# Altium schematic sheet Percepção_Externa.SchDoc(Percepção_Externa)
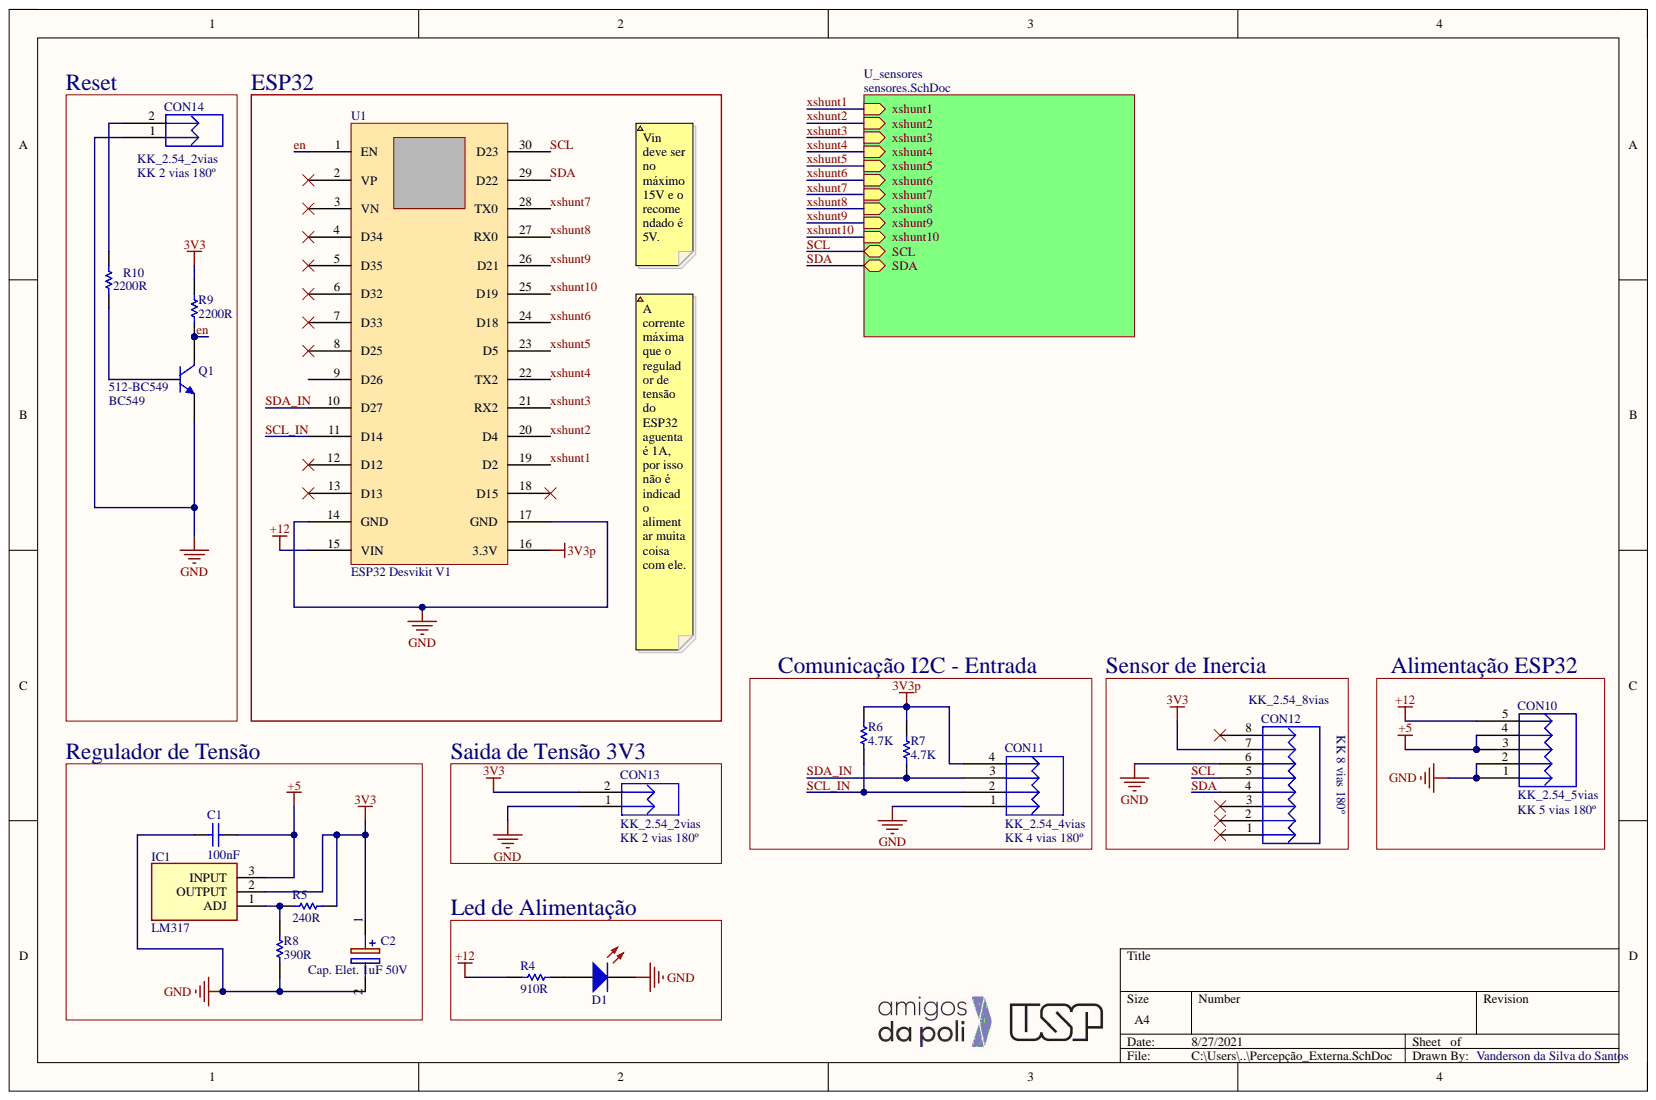
[diagram p0: Percepção_Externa.SchDoc]

## Parts
C1  100nF  [CAP CER DISK 100NF 50V]
C2  Cap. Elet. 1uF 50V  [Cap. Elet. 470uF 16V]
CON10  KK_2.54_5vias  Valor: KK 5 vias 180º  [KK_5vias_180°]
CON11  KK_2.54_4vias  Valor: KK 4 vias 180º  [KK_4vias_180°]
CON12  KK_2.54_8vias  Valor: KK 8 vias 180º  [KK_8vias_180°]
CON13  KK_2.54_2vias  Valor: KK 2 vias 180º  [KK_2VIAS_180º]
CON14  KK_2.54_2vias  Valor: KK 2 vias 180º  [KK_2VIAS_180º]
D1  LED 5MM RED  [LED 5MM RED]  (value not drawn)
IC1  LM317  [TO254P467X1016X1971-3P]
Q1  BC549  Supplier Part Number 1: 512-BC549  [TO92]
R4  Resistance (Ohms): 910R  [RES 470R 1/4W CARBON FILM]  (hidden comment: RES 470R 1/4W CARBON FILM)
R5  Resistance (Ohms): 240R  [RES 470R 1/4W CARBON FILM]  (hidden comment: RES 470R 1/4W CARBON FILM)
R6  4.7K  [RES 4.7K 1/4W CARBON FILM]
R7  4.7K  [RES 4.7K 1/4W CARBON FILM]
R8  Resistance (Ohms): 390R  [RES 470R 1/4W CARBON FILM]  (hidden comment: RES 470R 1/4W CARBON FILM)
R9  Resistance (Ohms): 2200R  [RES 470R 1/4W CARBON FILM]  (hidden comment: RES 470R 1/4W CARBON FILM)
R10  Resistance (Ohms): 2200R  [RES 470R 1/4W CARBON FILM]  (hidden comment: RES 470R 1/4W CARBON FILM)
U1  value ESP32 Desvikit V1  [ESP32_Desvikit_v1]  (hidden comment: microcontrolador)

## Nets
3V3: C2.1 CON12.7 CON13.2 IC1.2 R5.2 R9.2
3V3p: CON11.4 R6.1 R7.1 U1.16(3.3V)
+5: C1.2 CON10.3 CON10.4 IC1.3
+12: CON10.5 R4.2 U1.15(VIN)
GND: C1.1 C2.2 CON10.1 CON10.2 CON11.1 CON12.6 CON13.1 CON14.1 D1.2 Q1.3 R8.1 U1.14(GND) U1.17(GND)
en: Q1.1 R9.1 U1.1(EN)
SCL: CON12.5 U1.30(D23)
SCL_IN: CON11.2 R6.2 U1.11(D14)
SDA: CON12.4 U1.29(D22)
SDA_IN: CON11.3 R7.2 U1.10(D27)
xshunt1: U1.19(D2)
xshunt2: U1.20(D4)
xshunt3: U1.21(RX2)
xshunt4: U1.22(TX2)
xshunt5: U1.23(D5)
xshunt6: U1.24(D18)
xshunt7: U1.28(TX0)
xshunt8: U1.27(RX0)
xshunt9: U1.26(D21)
xshunt10: U1.25(D19)
NetCON12_1: CON12.1
NetCON12_2: CON12.2
NetCON12_3: CON12.3
NetCON12_8: CON12.8
NetCON14_2: CON14.2 R10.2
NetD1_1: D1.1 R4.1
NetIC1_1: IC1.1 R5.1 R8.2
NetQ1_2: Q1.2 R10.1
NetU1_2: U1.2(VP)
NetU1_3: U1.3(VN)
NetU1_4: U1.4(D34)
NetU1_5: U1.5(D35)
NetU1_6: U1.6(D32)
NetU1_7: U1.7(D33)
NetU1_8: U1.8(D25)
NetU1_9: U1.9(D26)
NetU1_12: U1.12(D12)
NetU1_13: U1.13(D13)
NetU1_18: U1.18(D15)

## Library data of the parts
C1 = CAP CER DISK 100NF 50V: Manufacturer Part Number: IMP14925; Capacitance: 0.1uF; Capacitance Tolerance: +80%, -20%; Capacitor Terminals: Radial Leaded; Dielectric Characteristic: Y5; Product Range: MCFY Series; SVHC: No SVHC (15-Jun-2015); Voltage Rating: 50; Capacitance Tolerance ±: +80%, -20%; Component Kind: Standard; ComponentLink1Description: http://loja.multcomercial.com.br/capacitor-ceramico-disco-100kpf-50v-104-100k-100nf-0-1uf-cod-1351.html; ComponentLink1URL: http://loja.multcomercial.com.br/capacitor-ceramico-disco-100kpf-50v-104-100k-100nf-0-1uf-cod-1351.html; Description: CAP CER DISK 100NF 50V; Lead Spacing: 5; Library Name: Capacitores_SCHLIB.SchLib; Manufacturer: -; Operating Temperature Max: 85; Operating Temperature Min: -25; Operating Temperature Range: -25°C to +85°C<!--FANDB--><div class="features-and-benefits-container"><div class="features-and-benefits-description"><span class="features-benefits-value">The MCFY series from Multicomp are radial leaded ceramic disc capacitors. The capacitance values ranges from 6800pF to 0.22?F.</span></div><div class="features-and-benefits-list"><ul class="features-and-benefits-list-list"><li class="features-and-benefits-list-item">Large capacitance in small size</li><li class="features-and-benefits-list-item">Rated voltage of 50V</li><li class="features-and-benefits-list-item">80%/-20% tolerance</li><li class="features-and-benefits-list-item">Operating temperature range -25°C to +85; Packaging: Each; Pricing 1: 1=0.10 (BRL); RoHS: YES; Stock 1: 115809; Supplier 1: Mult Comercial; Supplier Part Number 1: Capacitor Cerâmico Disco 100Kpf/50V (104/100K/100nF/0.1uF) cód. 1351
C2 = Cap. Elet. 470 uF 16V: Aluminum Organic Polymer Capacitors 16volts 470uF ESR 10mohm; Manufacturer_Name: Panasonic; Manufacturer_Part_Number: 16SEPC470M; Arrow Part Number: 16SEPC470M; Arrow Price/Stock: https://www.arrow.com/en/products/16sepc470m/panasonic; Component Kind: Standard; Datasheet Link: http://industrial.panasonic.com/www-cgi/jvcr13pz.cgi?E+CI+4+AAB8010+16SEPC470M+8+WW; Height: 13mm; Library Name: esp32.SchLib; Mouser Part Number: 667-16SEPC470M; Mouser Price/Stock: https://www.mouser.co.uk/ProductDetail/Panasonic/16SEPC470M?qs=OE1iw1LrrPEdZuWyF2l%252B5w%3D%3D
CON10 = KK_2.54_5vias: Conector KK 2.54mm 5 vias; Descrição: Conector KK 3 vias com passo 2.54mm; Encapsulamento: PTH - 180º; Modelo: 3 vias - 180º; Component Kind: Standard; Library Name: Conectores_Sch.SchLib
CON11 = KK_2.54_4vias: Conector KK 2.54mm 4 vias; Descrição: Conector KK 3 vias com passo 2.54mm; Encapsulamento: PTH - 180º; Modelo: 3 vias - 180º; Component Kind: Standard; Library Name: Conectores_Sch.SchLib
CON12 = KK_2.54_8vias: Conector KK 2.54mm 8 vias; Descrição: Conector KK 3 vias com passo 2.54mm; Encapsulamento: PTH - 180º; Modelo: 3 vias - 180º; Component Kind: Standard; Library Name: Conectores_Sch.SchLib
CON13 CON14 = KK_2.54_2vias: Conector KK 2.54mm 2 vias; Descrição: Conector KK 3 vias com passo 2.54mm; Encapsulamento: PTH - 180º; Modelo: 2 vias - 180º; Component Kind: Standard; Library Name: Conectores_Sch.SchLib
D1 = LED 5MM RED: Manufacturer: Paralight; Manufacturer Part Number: IMP585; Bulb Size: 3mm; Current If Luminous Intensity: 2; Dominant Wavelength: 625; External Diameter: 2.9; External Length / Height: 4.6; Forward Current If: 20; Forward Voltage VF Max: 2.5; Lead Cross-section Depth: 0.5; LED / Lamp Size: 3mm / T-1; LED Mounting: Through Hole; LED Type: Standard; Lens Shape: Round; Luminous Intensity: 3; Luminous Intensity @ If Min: 0.7; Peak Forward Current: 7; PIV Max: 5; SVHC: No SVHC (15-Jun-2015); Viewing Angle: 60°; Voltage Vf Typ: 2.2V<!--FANDB--><div class="features-and-benefits-container"><div class="features-and-benefits-description"><span class="features-benefits-value">The L-934LID from Kingbright is a through hole round shape high efficiency red colour LED. The device is made of gallium arsenide phosphide on gallium phosphide orange light emitting diode. This LED is widely used because of low power consumption, long life and solid state reliability.</span></div><div class="features-and-benefits-list"><ul class="features-and-benefits-list-list"><li class="features-and-benefits-list-item">Standard bulb size of T-1 (3mm)</li><li class="features-and-benefits-list-item">Reliable and rugged</li><li class="features-and-benefits-list-item">Viewing angle is 60°</li><li class="features-and-benefits-list-item">Luminous intensity of 3mcd at 2mA</li><li class="features-and-benefits-list-item">Forward voltage is 2.0V</li><li class="features-and-benefits-list-item">Forward current (If) is 20mA</li><li class="features-and-benefits-list-item">Dominant wavelength is 625nm at IF=20mA</li><li class="features-and-benefits-list-item">Peak wavelength is 627nm at IF=20; Component Kind: Standard; ComponentLink1Description: http://loja.multcomercial.com.br/led-3mm-vermelho-difuso-l-314hd-paralight.html; ComponentLink1URL: http://loja.multcomercial.com.br/led-3mm-vermelho-difuso-l-314hd-paralight.html; Description: LED 5MM RED; Forward Current If Max: 20; Forward Voltage: 2; Lead Cross-section Width: 0.5; Lead Spacing: 2.54; LED Colour: Red; Library Name: esp32.SchLib; Luminous Intensity Typ: 3; Mounting Type: PCB Through Hole; MSL: -; No. of Pins: 2; Operating Temperature Max: 85; Operating Temperature Min: -40; Operating Temperature Range: -40°C to +85; Packaging: Each; Peak Wavelength: 625; Pricing 1: 1=0.15 (BRL); RoHS: YES; Stock 1: 35260; Supplier 1: Mult Comercial; Supplier Part Number 1: Led 3mm Vermelho Difuso L-314HD - Paralight; Wavelength Typ: 625
IC1 = LM317: Integrated Circuit; Manufacturer_Name: Changjiang Electronics Tech (CJ); Component Kind: Standard; Datasheet Link: https://datasheet.lcsc.com/szlcsc/Changjiang-Electronics-Tech-CJ-LM317_C22147.pdf; Height: 4.67mm; Manufacturer_Part_Number: LM317
Q1 = BC549: TRANS NPN 30V 0.1A TO-92; Brand: Fairchild Semiconductor; Collector-Emitter Saturation Voltage: 200 mV; Configuration: Single; DC Collector/Base Gain hfe Min: 110; DC Current Gain hFE Max: 800; Emitter- Base Voltage VEBO: 5 V; Gain Bandwidth Product fT: 300 MHz; Maximum Power Dissipation: 0.5 W; Collector- Base Voltage VCBO: 30 V; Collector- Emitter Voltage VCEO Max: 30 V; Component Kind: Standard; ComponentLink1Description: https://datasheet.ciiva.com/982/bc546-982130.pdf; ComponentLink1URL: https://datasheet.ciiva.com/982/bc546-982130.pdf; ComponentLink2Description: https://datasheet.ciiva.com/17179/bc549-17179663.pdf; ComponentLink2URL: https://datasheet.ciiva.com/17179/bc549-17179663.pdf; Factory Pack Quantity: 2000; Manufacturer: Fairchild Semiconductor; Manufacturer Part Number: BC549; Maximum DC Collector Current: 0.1 A; Maximum Operating Temperature: + 150 C; Minimum Operating Temperature: - 65 C; Mounting Style: Through Hole; Package / Case: TO-92-3; Packaging: Bulk; Product Category: Bipolar Transistors - BJT; RoHS: Details; Series: BC549; Supplier 1: Mouser; Transistor Polarity: NPN; Library Name: PS_Balanca.SchLib
R4 R5 R8-R10 = RES 470R 1/4W CARBON FILM: RES 470R OHM 1/4W 5% CARBON FILM; Manufacturer: Ty-Ohm; Manufacturer Part Number: CR25; Power (Watts): 0.25W, 1/4W; Temperature Coefficient: 0/ -500ppm/°C; Category: Resistors; Component Kind: Standard; ComponentLink1Description: http://loja.multcomercial.com.br/resistor-de-1-4-de-watt-cr25-5-de-tolerancia.html; ComponentLink1URL: http://loja.multcomercial.com.br/resistor-de-1-4-de-watt-cr25-5-de-tolerancia.html; Composition: Carbon Film; Features: Flame Retardant Coating, Safety; Height: -; Library Name: esp32.SchLib; Number of Terminations: 2; Operating Temperature: -55°C ~ 155°C; Package / Case: Axial; Packaging: Cut Tape (CT); Pricing 1: 1=0.20 (BRL); RoHS: RoHS Compliant; Size / Dimension: 0.091" Dia x 0.256" L (2.30mm x 6.50mm); Stock 1: 617543; Supplier 1: Mult Comercial; Supplier Device Package: Axial; Supplier Part Number 1: Resistor de Filme de Carbono 1/4 de Watt CR25 5% de tolerância - 0.22R Ω à 10M Ω; Tolerance: ±5%
R6 R7 = RES 4.7K 1/4W CARBON FILM: RES 4.7K OHM 1/4W 5% CARBON FILM; Manufacturer: Ty-Ohm; Manufacturer Part Number: CR25; Power (Watts): 0.25W, 1/4W; Temperature Coefficient: 0/ -500ppm/°C; Category: Resistors; Component Kind: Standard; ComponentLink1Description: http://loja.multcomercial.com.br/resistor-de-1-4-de-watt-cr25-5-de-tolerancia.html; ComponentLink1URL: http://loja.multcomercial.com.br/resistor-de-1-4-de-watt-cr25-5-de-tolerancia.html; Composition: Carbon Film; Features: Flame Retardant Coating, Safety; Height: -; Library Name: esp32.SchLib; Number of Terminations: 2; Operating Temperature: -55°C ~ 155°C; Package / Case: Axial; Packaging: Cut Tape (CT); Pricing 1: 1=0.20 (BRL); Resistance (Ohms): ?; RoHS: RoHS Compliant; Size / Dimension: 0.091" Dia x 0.256" L (2.30mm x 6.50mm); Stock 1: 617543; Supplier 1: Mult Comercial; Supplier Device Package: Axial; Supplier Part Number 1: Resistor de Filme de Carbono 1/4 de Watt CR25 5% de tolerância - 0.22R Ω à 10M Ω; Tolerance: ±5%
U1 = ESP32 Desvikit v1: microcontrolador com moculo bluethoth e wifi; Component Kind: Standard; Library Name: esp32.SchLib
